# Altium schematic sheet power_level2.SchDoc
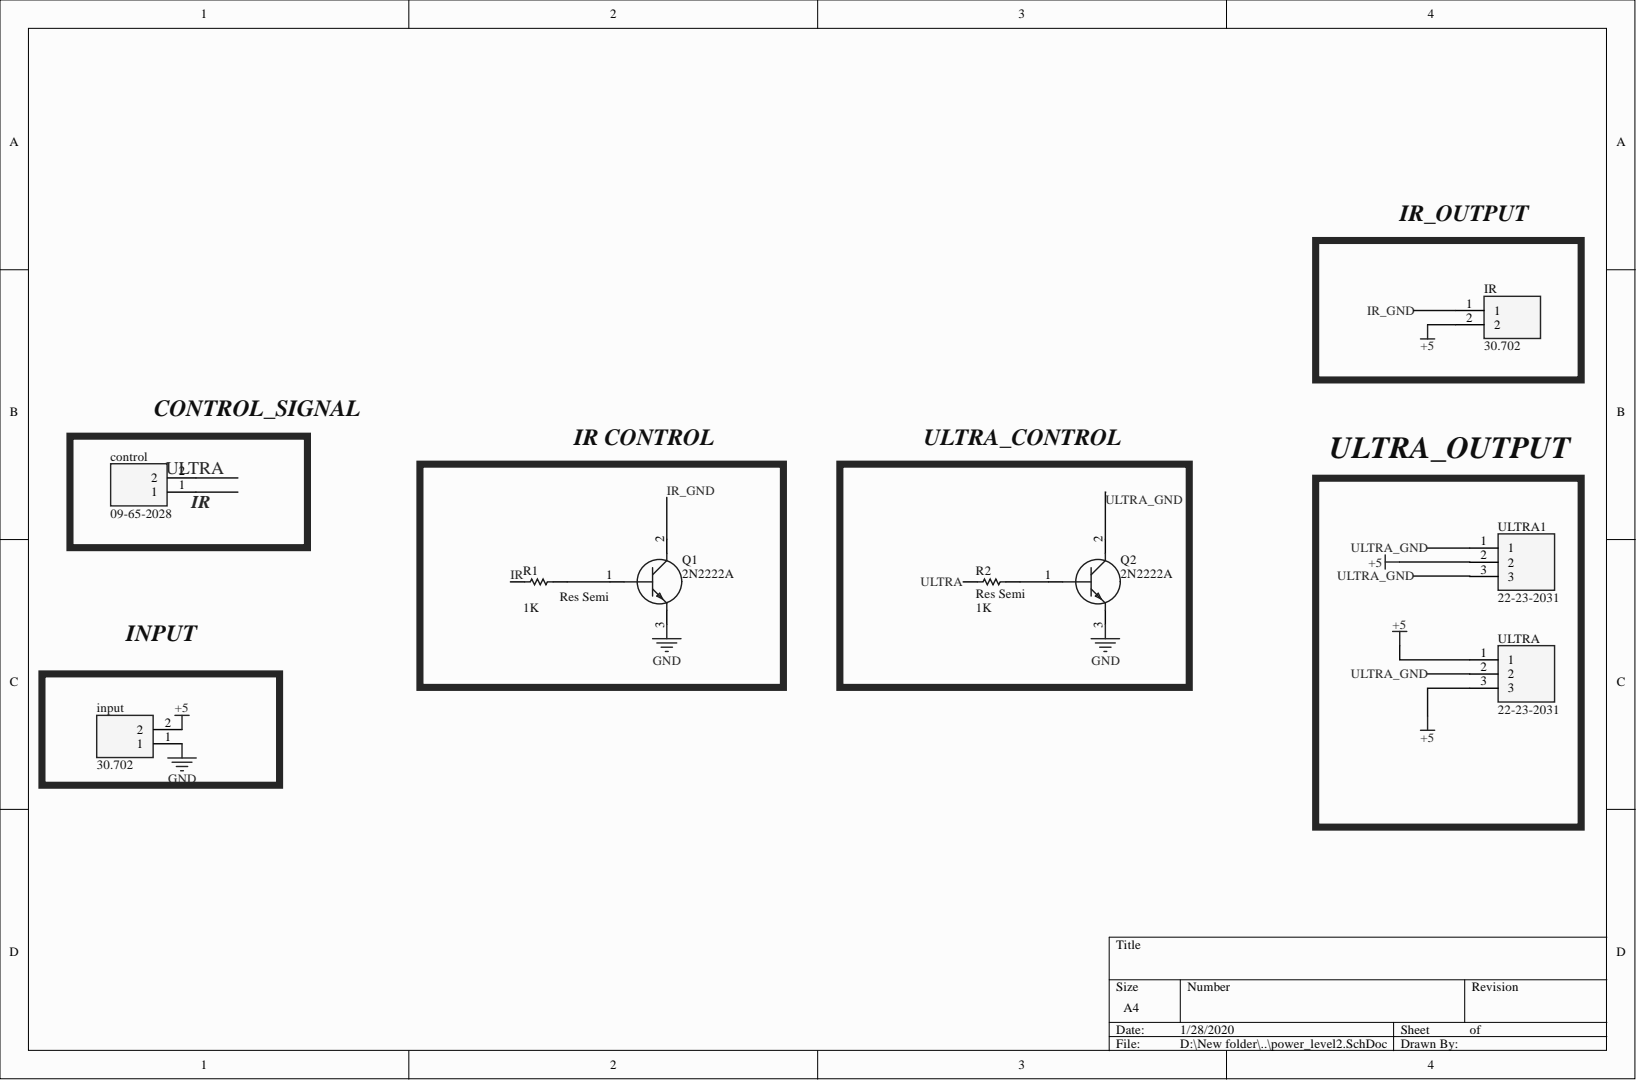
[diagram p0: power_level2.SchDoc]

## Parts
control  09-65-2028  [SHDR2W165P0X396_1X2_716X1062X1425P]
input  30.702  [SHDR2W90P0X500_1X2_1000X750X1000P]
IR  30.702  [SHDR2W90P0X500_1X2_1000X750X1000P]
Q1  2N2222A  [TO-18_4]
Q2  2N2222A  [TO-18_4]
R1  Res Semi  value 1K  [AXIAL-0.5]
R2  Res Semi  value 1K  [AXIAL-0.5]
ULTRA  22-23-2031  [SHDR3W87P0X254_1X3_747X635X843P]
ULTRA1  22-23-2031  [SHDR3W87P0X254_1X3_747X635X843P]

## Nets
+5: input.2 IR.2 ULTRA.1 ULTRA.3 ULTRA1.2
GND: input.1 Q1.3 Q2.3
IR: control.1 R1.1
IR_GND: IR.1 Q1.2
ULTRA: control.2 R2.1
ULTRA_GND: Q2.2 ULTRA.2 ULTRA1.1 ULTRA1.3
NetQ1_1: Q1.1 R1.2
NetQ2_1: Q2.1 R2.2

## Library data of the parts
control = 09-65-2028: Connector; Manufacturer_Name: Molex; Allied_Number: 70374002; Allied Price/Stock: https://www.alliedelec.com/molex-incorporated-09-65-2028/70374002/; Component Kind: Standard; Datasheet Link: https://www.molex.com/webdocs/datasheets/pdf/en-us/0009652028_PCB_HEADERS.pdf; Height: 14.25mm; Manufacturer_Part_Number: 09-65-2028; Mouser Part Number: 538-09-65-2028; Mouser Price/Stock: https://www.mouser.com/Search/Refine.aspx?Keyword=538-09-65-2028; RS Part Number: 6705237P; RS Price/Stock: http://uk.rs-online.com/web/p/products/6705237P
input IR = 30.702: Connector; Manufacturer_Name: Altech; Allied_Number: 70077464; Allied Price/Stock: https://www.alliedelec.com/altech-corp-30-702/70077464/; Component Kind: Standard; Datasheet Link: http://www.mouser.com/ds/2/16/PCBMETRC-24178.pdf; Height: 10mm; Manufacturer_Part_Number: 30.702; Mouser Part Number: 845-30.702; Mouser Price/Stock: https://www.mouser.com/Search/Refine.aspx?Keyword=845-30.702; RS Part Number: 8993792; RS Price/Stock: https://uk.rs-online.com/web/p/products/8993792
Q1 Q2 = 2N2222A: Transistor BJT NPN; Manufacturer_Name: STMicroelectronics; Component Kind: Standard; Datasheet Link: http://docs-emea.rs-online.com/webdocs/0e77/0900766b80e779e1.pdf; Manufacturer_Part_Number: 2N2222A; Mouser Part Number: 511-2N2222A; Mouser Price/Stock: https://www.mouser.com/Search/Refine.aspx?Keyword=511-2N2222A; RS Part Number: 7146715P; RS Price/Stock: http://uk.rs-online.com/web/p/products/7146715P
R1 R2 = Res Semi: Semiconductor Resistor; Component Kind: Standard; LatestRevisionDate: 17-Jul-2002; LatestRevisionNote: Re-released for DXP Platform.; Library Name: Miscellaneous Devices.IntLib; PackageDescription: Axial Device, Thru-Hole; 2 Leads; 0.5 in Pin Spacing; PackageReference: AXIAL-0.5; Published: 8-Jun-2000; Signal Integrity: Res Semi; Simulation: RES; Publisher: Altium Limited
ULTRA ULTRA1 = 22-23-2031: Connector; Manufacturer_Name: Molex; Allied_Number: 70190741; Allied Price/Stock: https://www.alliedelec.com/molex-incorporated-22-23-2031/70190741/; Component Kind: Standard; Datasheet Link: http://www.molex.com/webdocs/datasheets/pdf/en-us//0022232031_PCB_HEADERS.pdf; Height: 8.43mm; Manufacturer_Part_Number: 22-23-2031; Mouser Part Number: 538-22-23-2031; Mouser Price/Stock: https://www.mouser.com/Search/Refine.aspx?Keyword=538-22-23-2031; RS Part Number: 1888756; RS Price/Stock: http://uk.rs-online.com/web/p/products/1888756
